# Altium schematic sheet Dots.SchDoc(Dots)
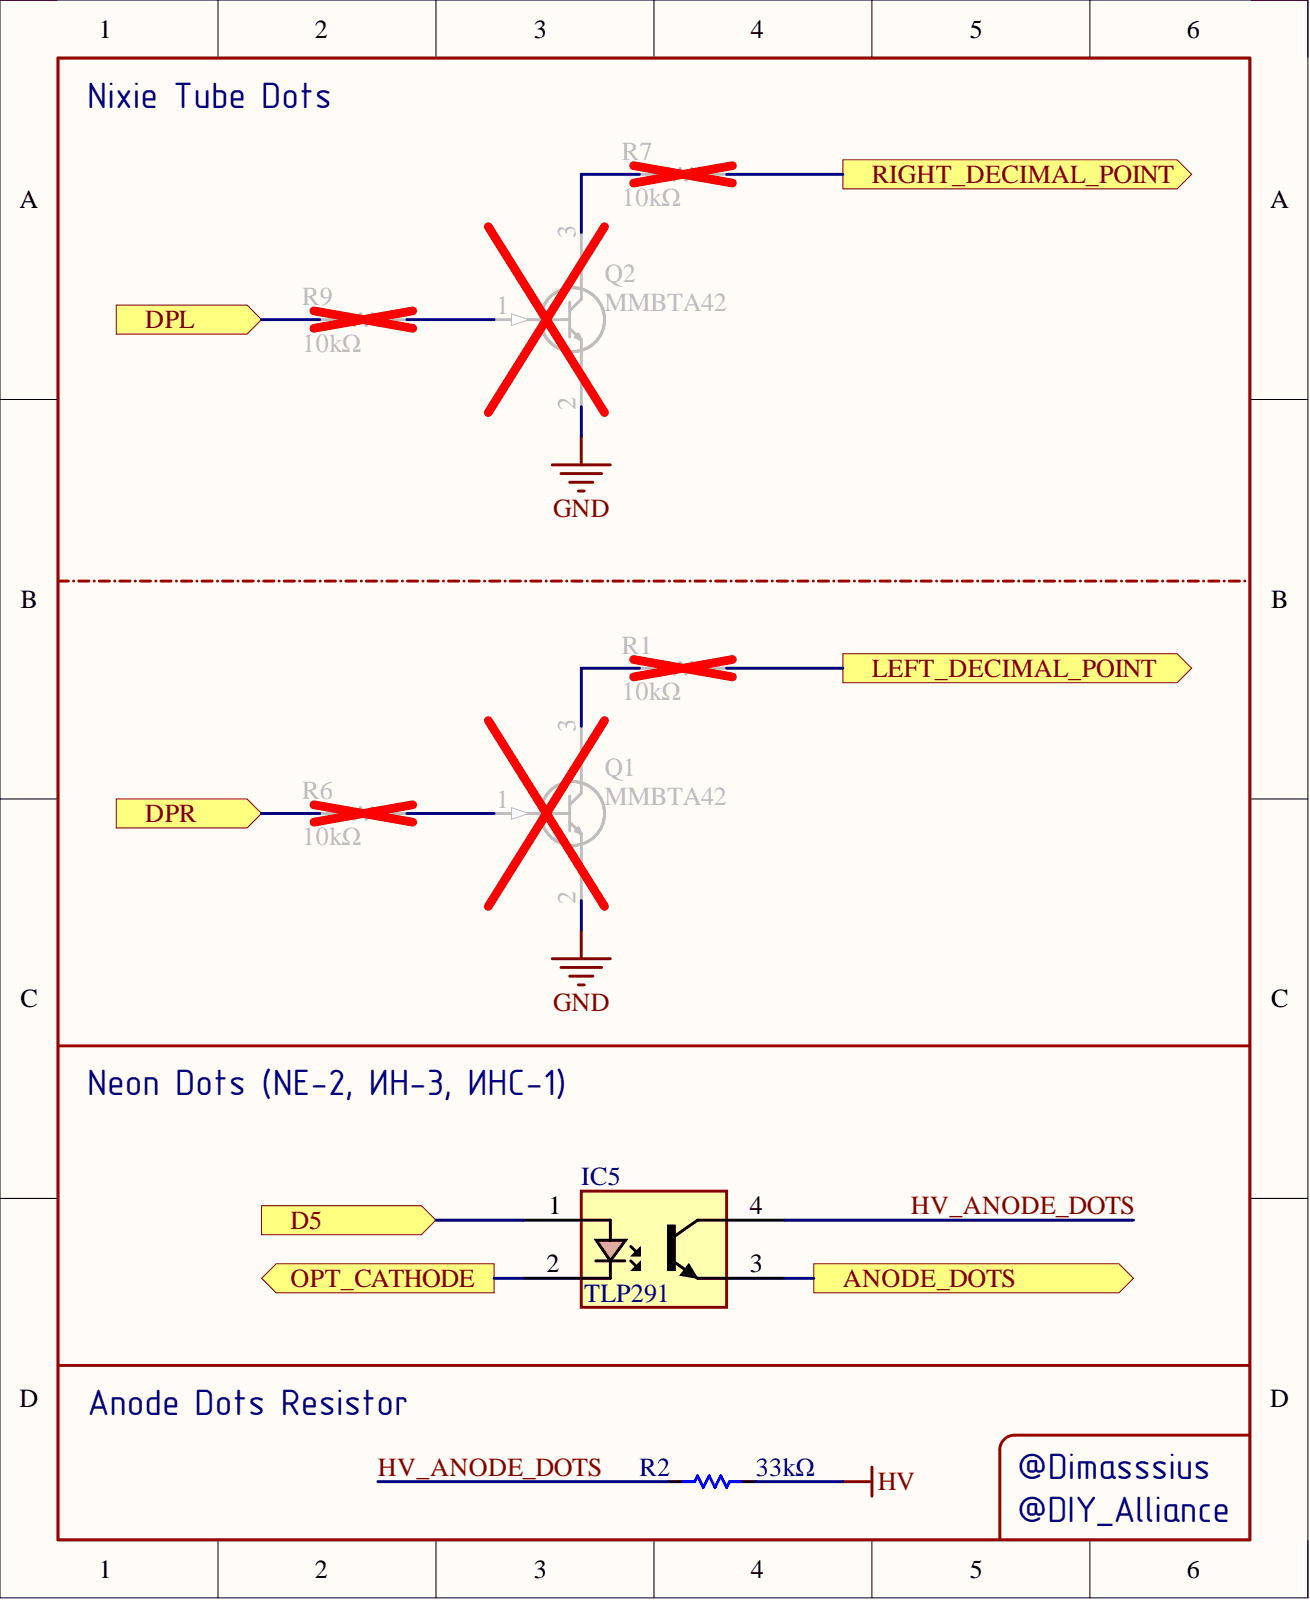
[diagram p0: Dots.SchDoc]

## Parts
IC5  TLP291  [SOIC127P700X230-4N]
Q1  MMBTA42  [SOT105P255X140-3N]
Q2  MMBTA42  [SOT105P255X140-3N]
R1  10kΩ  [RES_SMD_R0603_(M1608)_R0402A_(M1005A)]
R2  33kΩ  [RES_SMD_R0603_(M1608)_R0402A_(M1005A)]
R6  10kΩ  [RES_SMD_R0603_(M1608)_R0402A_(M1005A)]
R7  10kΩ  [RES_SMD_R0603_(M1608)_R0402A_(M1005A)]
R9  10kΩ  [RES_SMD_R0603_(M1608)_R0402A_(M1005A)]

## Ports
ANODE_DOTS, D5, DPL, DPR, LEFT_DECIMAL_POINT, OPT_CATHODE, RIGHT_DECIMAL_POINT

## Nets
GND: Q1.2 Q2.2
HV: R2.2
ANODE_DOTS: IC5.3 ; port ANODE_DOTS
D5: IC5.1 ; port D5
DPL: R9.1 ; port DPL
DPR: R6.1 ; port DPR
HV_ANODE_DOTS: IC5.4 R2.1
LEFT_DECIMAL_POINT: R1.2 ; port LEFT_DECIMAL_POINT
OPT_CATHODE: IC5.2 ; port OPT_CATHODE
RIGHT_DECIMAL_POINT: R7.2 ; port RIGHT_DECIMAL_POINT
NetQ1_1: Q1.1 R6.2
NetQ1_3: Q1.3 R1.1
NetQ2_1: Q2.1 R9.2
NetQ2_3: Q2.3 R7.1

## Library data of the parts
IC5 = TLP291: Photovoltaic Output Optoisolator, SOP-4-1.27mm, 80V, 3.75kV, 50mA, 300mV@2.4mA, 8mA, 1, 5V, 1.25V, DC  Transistor, Photovoltaic Output Optoisolators, ROHS; Component Kind: Standard; Datasheet Link: https://datasheet.datasheetarchive.com/originals/distributors/Datasheets-TOS/DSATOSJO10001087.pdf; Height: 2.3mm; Library Name: SamacSys.SchLib; Value: TLP291
Q1 Q2 = MMBTA42: Transistor Bipolar (BJT), NPN, SOT-23, 300V, 225mW, 25@10mA, 10V, 500mA, NPN Bipolar (BJT), ROHS; Component Kind: Standard; Datasheet Link: http://www.st.com/web/en/resource/technical/document/datasheet/CD00002980.pdf; Height: 1.4mm; Library Name: SamacSys.SchLib; Value: MMBTA42
R1 R6 R7 R9 = RES_SMD_10KΩ: Resistor, Thick Film Resistor, SMD, 10kΩ, ±5%, Chip Resistor - Surface Mount, ROHS; Component Kind: Standard; Library Name: Resistors.SchLib; Value: 10kΩ
R2 = RES_SMD_33KΩ: Resistor, Thick Film Resistor, SMD, 33kΩ, ±5%, Chip Resistor - Surface Mount, ROHS; Component Kind: Standard; Library Name: Resistors.SchLib; Value: 33kΩ
